# Altium schematic sheet P01_BB116_V2_Power BBCore.SchDoc("P01_BB116_V2_Power BBCore")
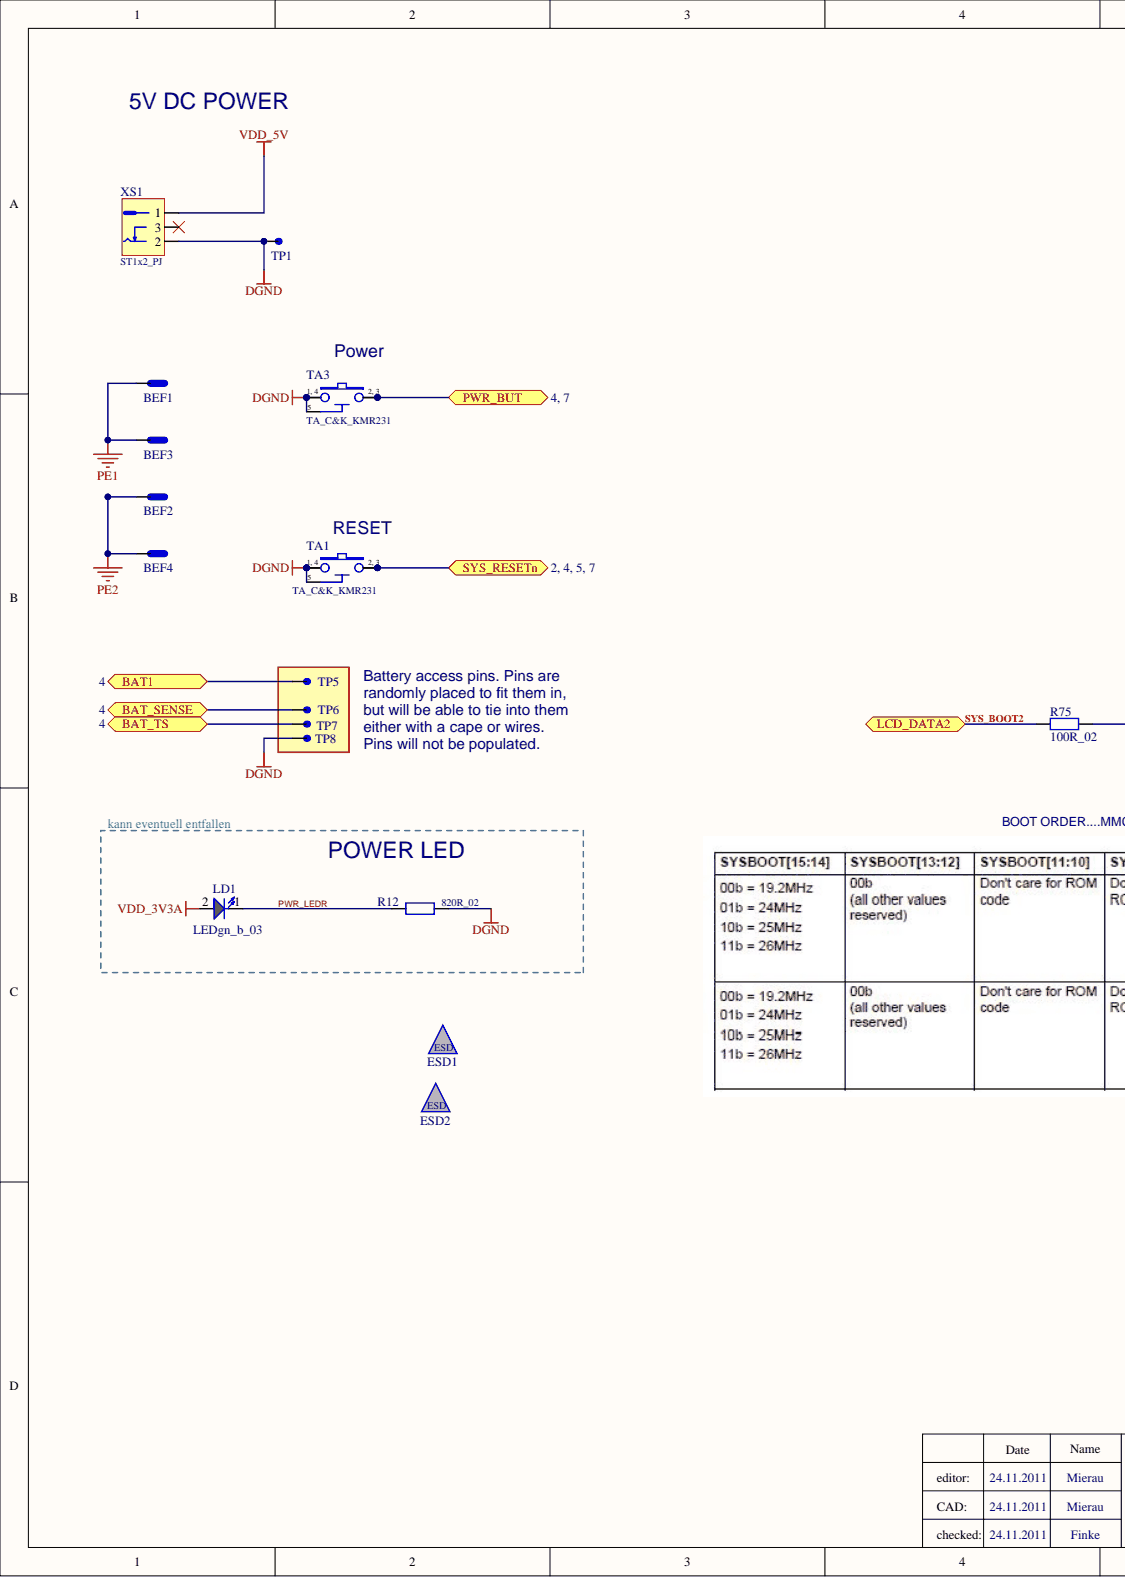
[diagram p0: P01_BB116_V2_Power BBCore.SchDoc, left]
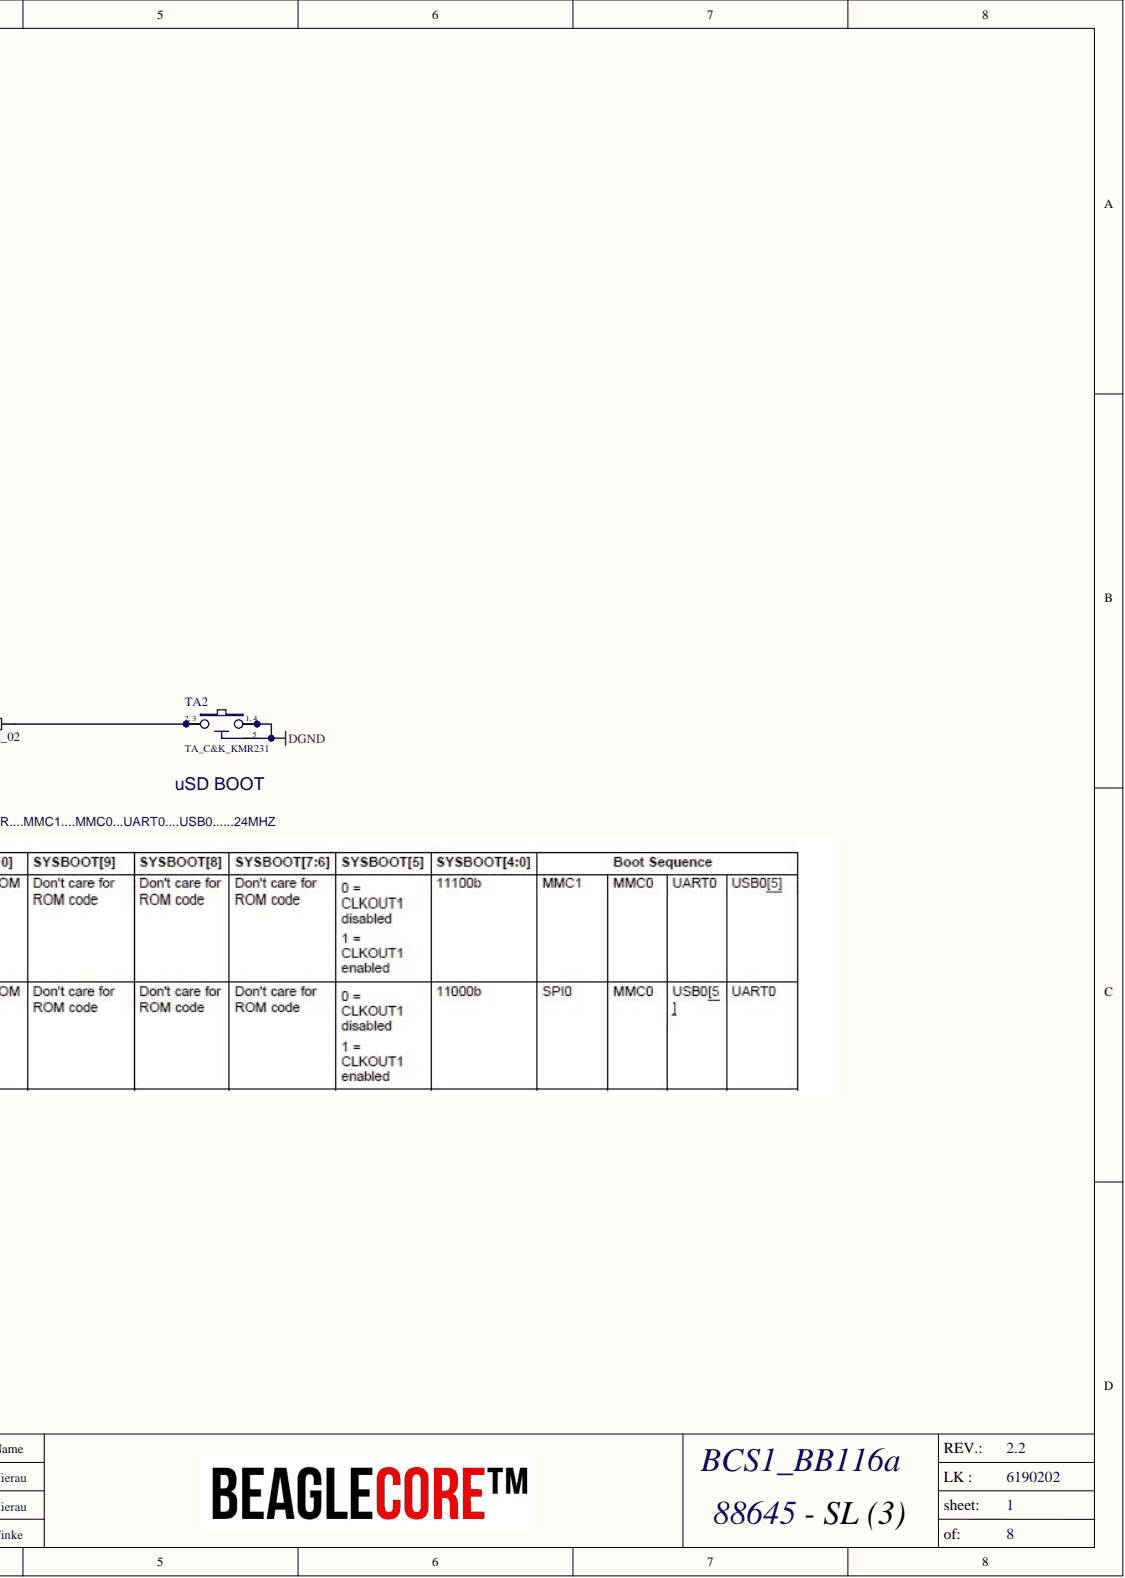
[diagram p1: P01_BB116_V2_Power BBCore.SchDoc, right]

## Parts
BEF1  BEF_3.2_4.45  [BEF_3.2_4.45]  (value not drawn)  (no BOM)
BEF2  BEF_3.2_4.45  [BEF_3.2_4.45]  (value not drawn)  (no BOM)
BEF3  BEF_3.2_4.45  [BEF_3.2_4.45]  (value not drawn)  (no BOM)
BEF4  BEF_3.2_4.45  [BEF_3.2_4.45]  (value not drawn)  (no BOM)
ESD1  ESD  [ESD_G]  (no BOM)
ESD2  ESD  [ESD_G]  (no BOM)
LD1  LEDgn_b_03  [CD1608-0603]
R12  820R_02  [CR1005-0402]
R75  100R_02  [CR1005-0402]
TA1  TA_C&K_KMR231  [TA_KMR2]
TA2  TA_C&K_KMR231  [TA_KMR2]
TA3  TA_C&K_KMR231  [TA_KMR2]
TP1  TP THT  [TP mit 0.8mm Bohrung]  (value not drawn)  (no BOM)
TP5  TP THT  [TP mit 0.8mm Bohrung]  (value not drawn)  (no BOM)
TP6  TP THT  [TP mit 0.8mm Bohrung]  (value not drawn)  (no BOM)
TP7  TP THT  [TP mit 0.8mm Bohrung]  (value not drawn)  (no BOM)
TP8  TP THT  [TP mit 0.8mm Bohrung]  (value not drawn)  (no BOM)
XS1  ST1x2_PJ  [ST1X2THT-2mm-DC_WA]

## Ports
BAT1, BAT_SENSE, BAT_TS, LCD_DATA2, PWR_BUT, SYS_RESETN

## Nets
DGND: R12.2 TA1.1 TA1.4 TA1.5 TA2.1 TA2.4 TA2.5 TA3.1 TA3.4 TA3.5 TP1.1 TP8.1 XS1.2
PE1: BEF1.1 BEF3.1
PE2: BEF2.1 BEF4.1
SYS_RESETN: TA1.2 TA1.3 ; port SYS_RESETN
VDD_3V3A: LD1.2
VDD_5V: XS1.1
BAT1: TP5.1 ; port BAT1
BAT_SENSE: TP6.1 ; port BAT_SENSE
BAT_TS: TP7.1 ; port BAT_TS
LCD_DATA2: R75.1 ; port LCD_DATA2
PWR_BUT: TA3.2 TA3.3 ; port PWR_BUT
PWR_LEDR: LD1.1 R12.1
NetR75_2: R75.2 TA2.2 TA2.3

Not connected: XS1 3

## Library data of the parts
BEF1-BEF4 = A_BEF_3.2_4.45: Befestigungsbohrung D=3.2mm_4.45_Rand; S/T: mech; Published: 24-Mar-1999; Revision: July-2002: Re-released for DXP Platform.; Value: BEF_3.2_4.45; Library Name: A_ies_Integrated_Library.IntLib
ESD1 ESD2 = A_ESD: ESD Symbol; S/T: mech; Published: 24-Mar-1999; Revision: July-2002: Re-released for DXP Platform.; Value: ESD; Library Name: A_ies_Integrated_Library.IntLib
LD1 = LD_LEDgn_bright_03: Leuchtdiode bright grün 0603, RV(5mA)@3V3= 100OHM; Art-Nr.: 05.0401.0022; Bestellbezeichnung: 150060VS75000; Hersteller: Würth Elektronik; S/T: SMD; Component Kind: Standard; ComponentLink1Description: LEDge_03 Datenblatt; Lieferant: Würth Elektronik; Value: LEDgn_b_03; ComponentLink1URL: u:\LD_Leuchtdioden\Wuerth\150060VS75000.pdf; Library Name: LD_ies_Integrated_Library.IntLib
R12 = R820R_02: Resistor 0402; Art-Nr.: 09.0002.; S/T: SMD; Component Kind: Standard; Value: 820R_02; Library Name: R_ies_Integrated_Library.IntLib
R75 = R100R_02: Resistor 0402 1%; Art-Nr.: 09.0001.0013; erstellt von: Finke; S/T: SMD; Component Kind: Standard; Revision: July-2002: Re-released for DXP Platform.; Value: 100R_02; Library Name: R_ies_Integrated_Library.IntLib
TA1-TA3 = TASTER_C&K_KMR231: Taster smd 1pol mm pitch, 4.6x2.8mm case; Art-Nr.: 10.0100.00__; Bestellbezeichnung: KMR231GLFS; erstellt von: Finke; Hersteller: C&K; Component Kind: Standard; ComponentLink1Description: Datenblatt; ComponentLink2Description: Masszeichnungen; S/T: SMD; Value: TA_C&K_KMR231; ComponentLink1URL: u:\TA u SH_Taster u Schalter\c&k\KMR2_9aug12.pdf; ComponentLink2URL: u:\TA u SH_Taster u Schalter\c&k\CU78M2G001FP-Q.pdf; Library Name: TA_ies_Integrated_Library.IntLib
TP1 TP5-TP8 = TP1.6 mit Bohrung 0.8: Testpunkt rund mit Bohrung D=0.8mm; erstellt von: Finke; S/T: mech; Published: 24-Mar-1999; Revision: July-2002: Re-released for DXP Platform.; Value: TP THT; Library Name: TP_ies_Integrated_Library.IntLib
XS1 = XST1X2_PJ_WA: DC-Power-Jack, 2mm Stift, 5A, THT,gew.; Art-Nr.: 03.0__0.00__; Bestellbezeichnung: PJ-002AH; erstellt von: Finke; Hersteller: CUI; Component Kind: Standard; ComponentLink1Description: Datenblatt; S/T: THT; Value: ST1x2_PJ; ComponentLink1URL: u:\X_steckverbinder\CUI\pj-002ah.pdf; Library Name: X_ies_Integrated_Library.IntLib
